# Altium schematic sheet Module_Reference.SchDoc(Module_Reference)
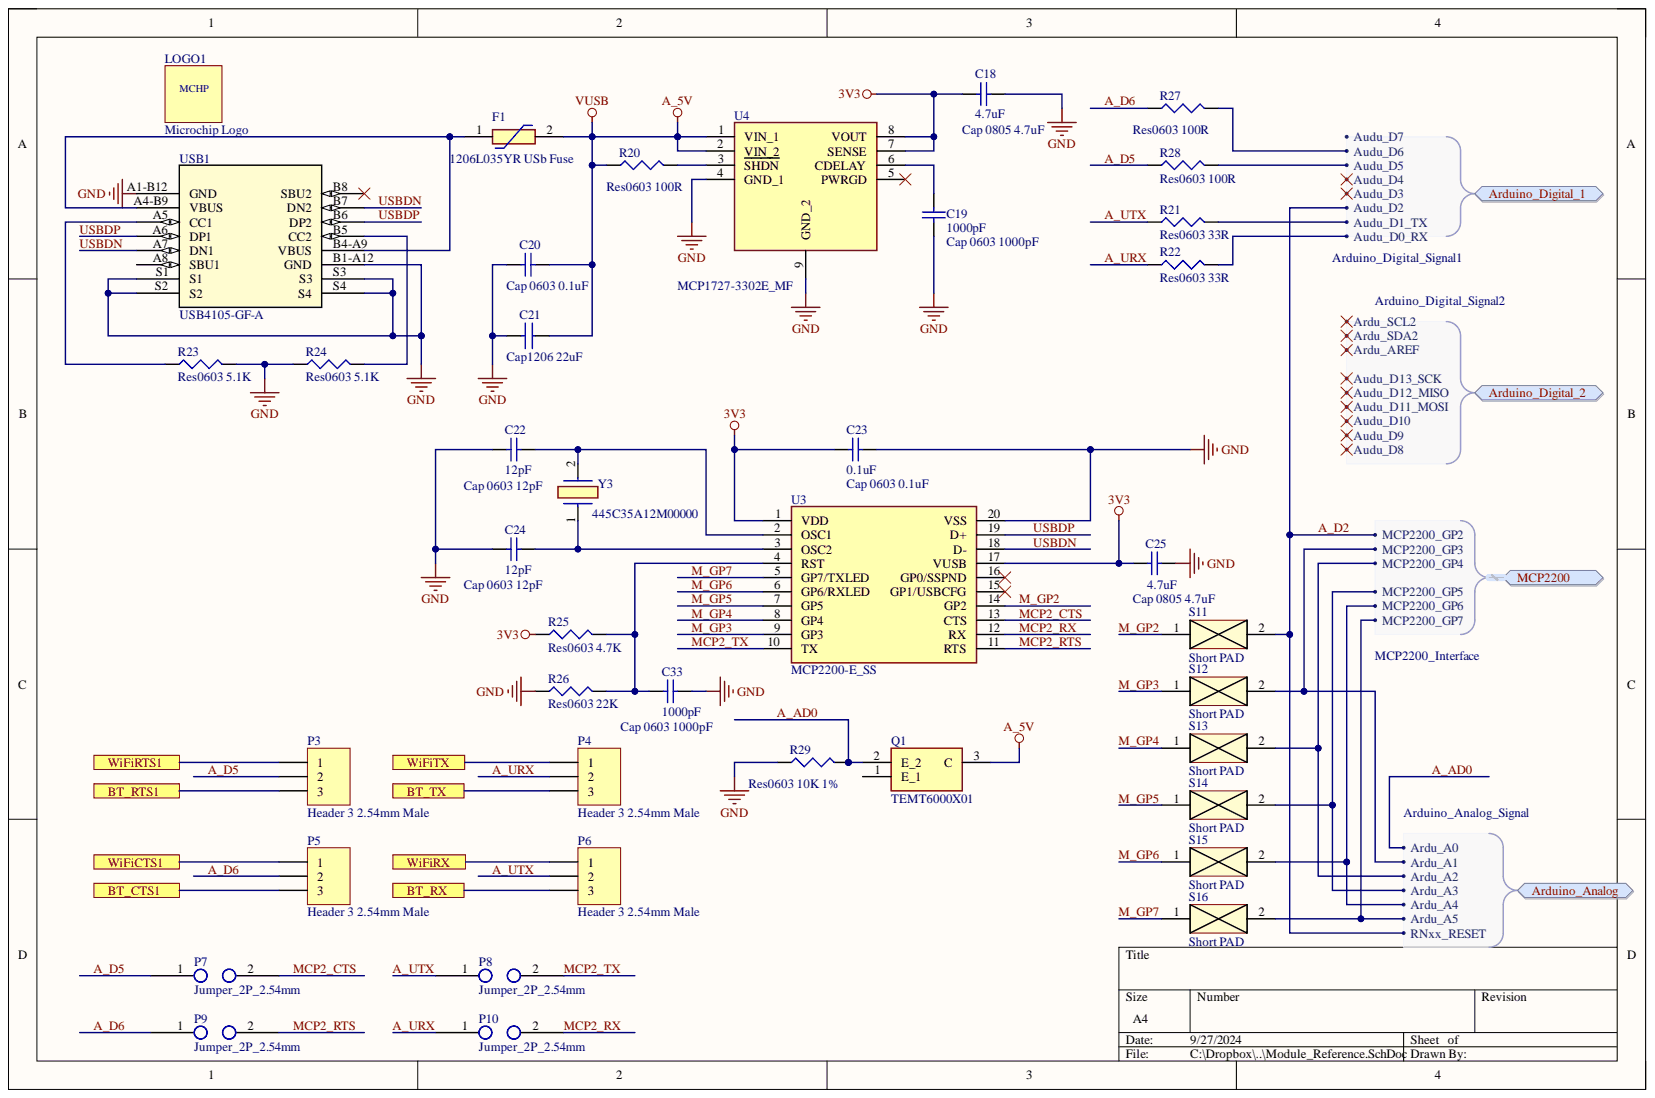
[diagram p0: Module_Reference.SchDoc]

## Parts
C18  Cap 0805 4.7uF  value 4.7uF  [C0805]
C19  Cap 0603 1000pF  value 1000pF  [0603C]
C20  Cap 0603 0.1uF  value 0.1uF  [0603C]
C21  Cap1206 22uF  value 22uF  [AP1-00026]
C22  Cap 0603 12pF  value 12pF  [0603C]
C23  Cap 0603 0.1uF  value 0.1uF  [0603C]
C24  Cap 0603 12pF  value 12pF  [0603C]
C25  Cap 0805 4.7uF  value 4.7uF  [C0805]
C33  Cap 0603 1000pF  value 1000pF  [0603C]
F1  1206L035YR USb Fuse  [1206L05015YR]
LOGO1  Microchip Logo  [MCHP_LOGO_New]
P3  Header 3 2.54mm Male  [HDR1X3]
P4  Header 3 2.54mm Male  [HDR1X3]
P5  Header 3 2.54mm Male  [HDR1X3]
P6  Header 3 2.54mm Male  [HDR1X3]
P7  Jumper_2P_2.54mm  [HDR1X2]
P8  Jumper_2P_2.54mm  [HDR1X2]
P9  Jumper_2P_2.54mm  [HDR1X2]
P10  Jumper_2P_2.54mm  [HDR1X2]
Q1  TEMT6000X01  [TEMT6000X01]
R20  Res0603 100R  value 100R  [0603R]
R21  Res0603 33R  value 33R  [0603R]
R22  Res0603 33R  value 33R  [0603R]
R23  Res0603 5.1K  value 5.1K  [0603R]
R24  Res0603 5.1K  value 5.1K  [0603R]
R25  Res0603 4.7K  value 4.7K  [0603R]
R26  Res0603 22K  value 22K  [0603R]
R27  Res0603 100R  value 100R  [0603R]
R28  Res0603 100R  value 100R  [0603R]
R29  Res0603 10K 1%  value 10K 1%  [0603R]
S11  Short PAD  [Short_PAD]
S12  Short PAD  [Short_PAD]
S13  Short PAD  [Short_PAD]
S14  Short PAD  [Short_PAD]
S15  Short PAD  [Short_PAD]
S16  Short PAD  [Short_PAD]
U3  MCP2200-E_SS  [SOP65P780X200-20N]
U4  MCP1727-3302E_MF  [SON65P300X300X100-9N-D]
USB1  USB4105-GF-A  [USB4105_GCT]
Y3  445C35A12M00000  [445C35A12M00000]

## Ports
Arduino_Analog, Arduino_Analog.Ardu_A0, Arduino_Analog.Ardu_A1, Arduino_Analog.Ardu_A2, Arduino_Analog.Ardu_A3, Arduino_Analog.Ardu_A4, Arduino_Analog.Ardu_A5, Arduino_Analog.RNxx_RESET, Arduino_Digital_1, Arduino_Digital_1.Audu_D0_RX, Arduino_Digital_1.Audu_D1_TX, Arduino_Digital_1.Audu_D2, Arduino_Digital_1.Audu_D3, Arduino_Digital_1.Audu_D4, Arduino_Digital_1.Audu_D5, Arduino_Digital_1.Audu_D6, Arduino_Digital_1.Audu_D7, Arduino_Digital_2, Arduino_Digital_2.Ardu_AREF, Arduino_Digital_2.Ardu_SCL2, Arduino_Digital_2.Ardu_SDA2, Arduino_Digital_2.Audu_D8, Arduino_Digital_2.Audu_D9, Arduino_Digital_2.Audu_D10, Arduino_Digital_2.Audu_D11_MOSI, Arduino_Digital_2.Audu_D12_MISO, Arduino_Digital_2.Audu_D13_SCK, BT_CTS1, BT_RTS1, BT_RX, BT_TX, MCP2200, MCP2200.MCP2200_GP2, MCP2200.MCP2200_GP3, MCP2200.MCP2200_GP4, MCP2200.MCP2200_GP5, MCP2200.MCP2200_GP6, MCP2200.MCP2200_GP7, WiFiCTS1, WiFiRTS1, WiFiRX, WiFiTX

## Nets
3V3: C18.2 C23.1 C25.1 R25.1 U3.1(VDD) U3.17(VUSB) U4.7(SENSE) U4.8(VOUT)
A_5V: C20.2 C21.1 F1.2 Q1.3 R20.1 U4.1(VIN_1) U4.2(VIN_2)
GND: C18.1 C19.1 C20.1 C21.2 C22.1 C23.2 C24.1 C25.2 C33.2 R23.2 R24.1 R26.1 R29.1 U3.20(VSS) U4.4(GND_1) U4.9(GND_2) USB1.A1-B12(GND) USB1.B1-A12(GND) USB1.S1 USB1.S2 USB1.S3 USB1.S4
A_AD0: Q1.2 R29.2 ; port Arduino_Analog
A_D2: S11.2 ; port Arduino_Analog, port Arduino_Digital_1, port MCP2200
A_D5: P3.2 P7.1 R28.1
A_D6: P5.2 P9.1 R27.1
A_URX: P4.2 P10.1 R22.1
A_UTX: P6.2 P8.1 R21.1
BT_CTS1: P5.3 ; port BT_CTS1
BT_RTS1: P3.3 ; port BT_RTS1
BT_RX: P6.3 ; port BT_RX
BT_TX: P4.3 ; port BT_TX
M_GP2: S11.1 U3.14(GP2)
M_GP3: S12.1 U3.9(GP3)
M_GP4: S13.1 U3.8(GP4)
M_GP5: S14.1 U3.7(GP5)
M_GP6: S15.1 U3.6(GP6/RXLED)
M_GP7: S16.1 U3.5(GP7/TXLED)
MCP2_CTS: P7.2 U3.13(CTS)
MCP2_RTS: P9.2 U3.11(RTS)
MCP2_RX: P10.2 U3.12(RX)
MCP2_TX: P8.2 U3.10(TX)
USBDN: U3.18(D-) USB1.A7(DN1) USB1.B7(DN2)
USBDP: U3.19(D+) USB1.A6(DP1) USB1.B6(DP2)
WiFiCTS1: P5.1 ; port WiFiCTS1
WiFiRTS1: P3.1 ; port WiFiRTS1
WiFiRX: P6.1 ; port WiFiRX
WiFiTX: P4.1 ; port WiFiTX
NetC19_2: C19.2 U4.6(CDELAY)
NetC22_2: C22.2 U3.2(OSC1) Y3.2
NetC24_2: C24.2 U3.3(OSC2) Y3.1
NetC33_1: C33.1 R25.2 R26.2 U3.4(RST)
NetF1_1: F1.1 USB1.A4-B9(VBUS) USB1.B4-A9(VBUS)
NetR20_2: R20.2 U4.3(SHDN)
NetR21_2: R21.2 ; port Arduino_Digital_1
NetR22_2: R22.2 ; port Arduino_Digital_1
NetR23_1: R23.1 USB1.A5(CC1)
NetR24_2: R24.2 USB1.B5(CC2)
NetR27_2: R27.2 ; port Arduino_Digital_1
NetR28_2: R28.2 ; port Arduino_Digital_1
NetS12_2: S12.2 ; port Arduino_Analog, port MCP2200
NetS13_2: S13.2 ; port Arduino_Analog, port MCP2200
NetS14_2: S14.2 ; port Arduino_Analog, port MCP2200
NetS15_2: S15.2 ; port Arduino_Analog, port MCP2200
NetS16_2: S16.2 ; port Arduino_Analog, port MCP2200
N00020: ; port Arduino_Digital_1
N00026: ; port Arduino_Digital_1
N00028: ; port Arduino_Digital_1
N00034: ; port Arduino_Digital_2
N00036: ; port Arduino_Digital_2
N00038: ; port Arduino_Digital_2
N00041: ; port Arduino_Digital_2
N00044: ; port Arduino_Digital_2
N00047: ; port Arduino_Digital_2
N00050: ; port Arduino_Digital_2
N00053: ; port Arduino_Digital_2
N00055: ; port Arduino_Digital_2

Not connected: Q1 1; U3 15(GP1/USBCFG) 16(GP0/SSPND); U4 5(PWRGD); USB1 A8(SBU1) B8(SBU2)

## Library data of the parts
C18 C25 = Cap 0805: Capacitor (Semiconductor SIM Model); Component Kind: Standard; LatestRevisionDate: 29-May-2009; LatestRevisionNote: IPC-7351 Footprint Added.; Library Name: MCHP_Parts_2016.SchLib; PackageDescription: Chip Capacitor; PackageReference: C1206; Published: 8-Jun-2000; Publisher: Altium Limited
C19 C20 C22-C24 C33 = Cap 0603: Capacitor (Semiconductor SIM Model); Component Kind: Standard; LatestRevisionDate: 29-May-2009; LatestRevisionNote: IPC-7351 Footprint Added.; Library Name: MCHP_Parts_2016.SchLib; PackageDescription: Chip Capacitor; PackageReference: C0603; Published: 8-Jun-2000; Publisher: Altium Limited
C21 = Cap1206 Ceramic: Ceramic capacitor, SMD 1206, X7R, 16V, Â±10 %; Manufacturer: Murata; MPN: GRM31CR71C106KA12L; PartGroup: Capacitors,Ceramic,SMD 1206; AN: A01-0289; TemperatureRange: -55 - 125 deg C; Tolerance: Â±10 %; Component Kind: Standard; Height: 1,79999995231628; Library Name: MCHP_Parts_2016.SchLib; Verified date: 2005-12-15
F1 = 1206L035YR: Resettable Fuse; Manufacturer_Name: LITTELFUSE; Arrow Part Number: 1206L035YR; Arrow Price/Stock: https://www.arrow.com/en/products/1206l035yr/littelfuse?region=nac; Component Kind: Standard; Datasheet Link: https://m.littelfuse.com/~/media/electronics/datasheets/resettable_ptcs/littelfuse_ptc_1206l_datasheet.pdf.pdf; Height: 0.75mm; Manufacturer_Part_Number: 1206L035YR; Mouser Part Number: 576-1206L035YR; Mouser Price/Stock: https://www.mouser.co.uk/ProductDetail/Littelfuse/1206L035YR?qs=PWhpLWeW8wd%2F%252B9d2B1EXww%3D%3D
P3-P6 = Header 3: Header, 3-Pin; Component Kind: Standard; LatestRevisionDate: 17-Jul-2002; LatestRevisionNote: Re-released for DXP Platform.; Library Name: MCHP_Parts_2016.SchLib; Publisher: Altium Limited
Q1 = TEMT6000X01: Phototransistor; Manufacturer_Name: Vishay; Arrow Part Number: TEMT6000X01; Arrow Price/Stock: https://www.arrow.com/en/products/temt6000x01/vishay?region=europe; Component Kind: Standard; Datasheet Link: https://www.vishay.com/docs/81579/temt6000.pdf; Manufacturer_Part_Number: TEMT6000X01; Mouser Part Number: 78-TEMT6000; Mouser Price/Stock: https://www.mouser.co.uk/ProductDetail/Vishay-Semiconductors/TEMT6000X01?qs=%2Fjqivxn91ccZGXDwz0wGxg%3D%3D
R20-R29 = Res0603: Resistor; Component Kind: Standard; LatestRevisionDate: 29-May-2009; LatestRevisionNote: IPC-7351 Footprint Added.; Library Name: MCHP_Parts_2016.SchLib; PackageDescription: Chip Resistor; PackageReference: J1-0603; Published: 8-Jun-2000; Publisher: Altium Limited
S11-S16 = Short_Pads: Component Kind: Standard; Library Name: MCHP_Parts_2016.SchLib
U3 = MCP2200-E_SS: Integrated Circuit; Manufacturer_Name: Microchip; Manufacturer_Part_Number: MCP2200-E/SS; Component Kind: Standard; Datasheet Link: http://ww1.microchip.com/downloads/en/devicedoc/200022228d.pdf; Height: 2mm; Mouser Part Number: 494-MCP2200-E/SS; Mouser Price/Stock: https://www.mouser.co.uk/ProductDetail/Microchip-Technology/MCP2200-E-SS?qs=W%2FMpXkg%252BdQ4tQo7NYLK%2FfA%3D%3D
U4 = MCP1727-3302E_MF: Integrated Circuit; Manufacturer_Name: Microchip; Manufacturer_Part_Number: MCP1727-3302E/MF; Arrow Part Number: MCP1727-3302E/MF; Arrow Price/Stock: https://www.arrow.com/en/products/mcp1727-3302emf/microchip-technology?region=europe; Component Kind: Standard; Datasheet Link: http://ww1.microchip.com/downloads/en/DeviceDoc/21999b.pdf; Height: 1mm; Mouser Part Number: 579-MCP1727-3302E/MF; Mouser Price/Stock: https://www.mouser.co.uk/ProductDetail/Microchip-Technology/MCP1727-3302E-MF?qs=usxtMOJb1Ry%252BaKwf56olNA%3D%3D
USB1 = USB4105-GF-A: USB Type-C; Mfr_Name: Global Connector Technology; Component Kind: Standard; Library Name: MCHP_Parts_2016.SchLib; RefDes: RefDes; Type: Type
Y3 = 445C35A12M00000: Crystal or Oscillator; Manufacturer_Name: CTS; Component Kind: Standard; Datasheet Link: https://www.ctscorp.com/wp-content/uploads/445.pdf; Height: 1.35mm; Manufacturer_Part_Number: 445C35A12M00000; Mouser Part Number: 774-445C35A12M00000; Mouser Price/Stock: https://www.mouser.co.uk/ProductDetail/CTS-Electronic-Components/445C35A12M00000?qs=FP1Nkeq3mZ8saxLyk%252BsPkA%3D%3D
LOGO1 = Microchip Logo: Component Kind: Standard; Library Name: MCHP_Parts_2016.SchLib
P7-P10 = Jumper_2P_2.54mm: Component Kind: Standard; Library Name: MCHP_Parts_2016.SchLib
